# Altium schematic sheet Top Level.SchDoc
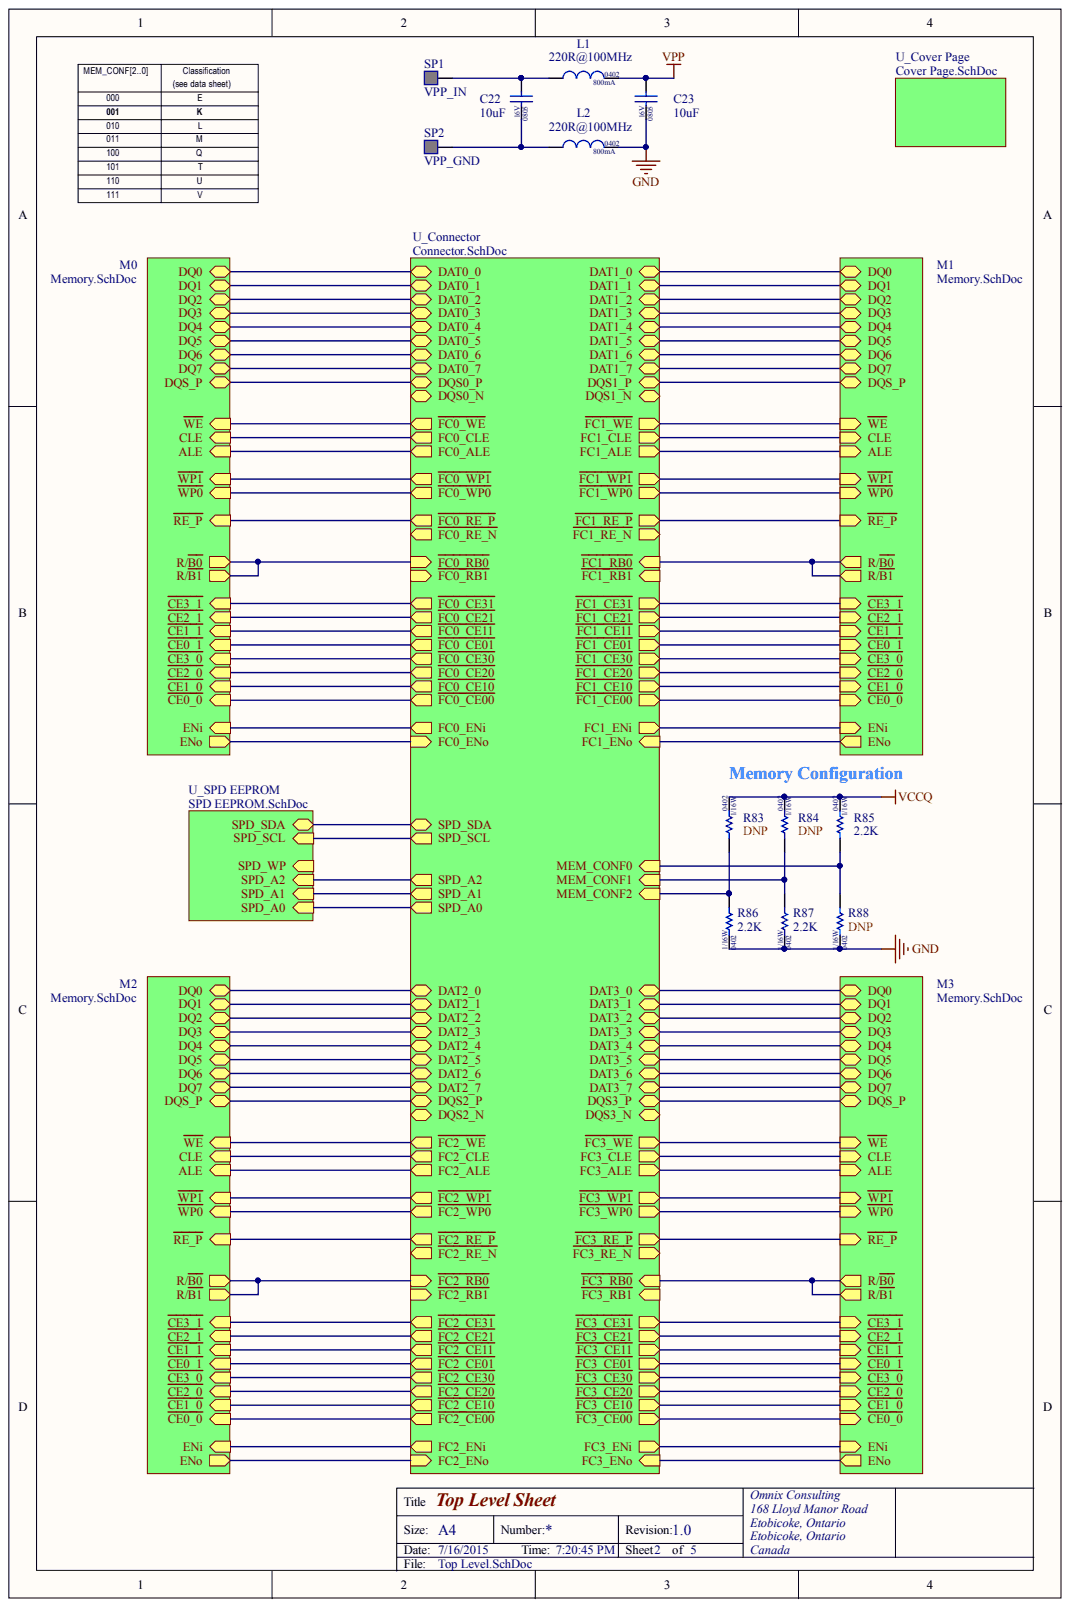
[diagram p0: Top Level.SchDoc]

## Parts
C22  10uF  Package: 0805
C23  10uF  Package: 0805
L1  220R@100MHz
L2  220R@100MHz
R83  DNP
R84  DNP
R85  2.2K
R86  2.2K
R87  2.2K
R88  DNP
SP1  VPP_IN
SP2  VPP_GND

## Nets
GND: C23.2 L2.2 R86.1 R87.1 R88.1
VCCQ: R83.1 R84.1 R85.1
VPP: C23.1 L1.2
NetC22_1: C22.1 L1.1 SP1.1
NetC22_2: C22.2 L2.1 SP2.1
NetR83_2: R83.2 R86.2
NetR84_2: R84.2 R87.2
NetR85_2: R85.2 R88.2
~{CE0_0}:
~{CE0_1}:
~{CE1_0}:
~{CE1_1}:
~{CE2_0}:
~{CE2_1}:
~{CE3_0}:
~{CE3_1}:
~{FC0_RB1}:
~{FC0_RE_N}:
~{FC1_RB1}:
~{FC1_RE_N}:
~{FC2_RB1}:
~{FC2_RE_N}:
~{FC3_RB1}:
~{FC3_RE_N}:
~{RE_P}:
~{WE}:
~{WP0}:
~{WP1}:
ALE:
CLE:
DQ0:
DQ1:
DQ2:
DQ3:
DQ4:
DQ5:
DQ6:
DQ7:
DQS0_N:
DQS1_N:
DQS2_N:
DQS3_N:
DQS_P:
ENI:
ENO:
R/~{B0}:
SPD_A0:
SPD_A1:
SPD_A2:
SPD_SCL:
SPD_SDA:
SPD_WP:

## Library data of the parts
C22 C23 = (no symbol name): Manufacturer: Samsung Electro-Mechanics America; D P/N: 1276-1096-1-ND; D Price: 0.2; M P/N: CL21A106KOQNNNE; S MOQ: 2000; S P/N: 1276-1096-2-ND; S Price: 0.0329; Distributor: Digikey; Mounting: SM; Supplier: Digi Key; Value: 10uF
L1 L2 = (no symbol name): Manufacturer: Taiyo Yuden; D P/N: 587-2088-1-ND; D Price: 0.1; M P/N: BKP1005HS221-T; S P/N: 587-2088-2-ND; S MOQ: 10000; S Price: 0.01503; Value: 220Ohm; Distributor: Digikey; Mounting: SM; Supplier: Digi Key
R83 R84 R88 = (no symbol name): Manufacturer: Yageo; D P/N: 311-2.20KLRCT-ND; D Price: 0.1; M P/N: RC0402FR-072K2L; S MOQ: 1000; S Price: 0.00268; Value: 2.2K; Distributor: Digikey; Mounting: SM; S P/N: 311-2.20KLRCT-ND; Supplier: Digi Key
R85-R87 = (no symbol name): Manufacturer: Yageo; D P/N: 311-2.20KLRCT-ND; D Price: 0.1; M P/N: RC0402FR-072K2L; S MOQ: 1000; S Price: 0.00268; Distributor: Digikey; Mounting: SM; S P/N: 311-2.20KLRCT-ND; Supplier: Digi Key; Value: 2.2K
SP1 SP2 = (no symbol name): D P/N: SOLDP; D Price: 0; M P/N: SOLDP; Mounting: SM
